# Altium schematic sheet Periferico.SchDoc(Periferico)
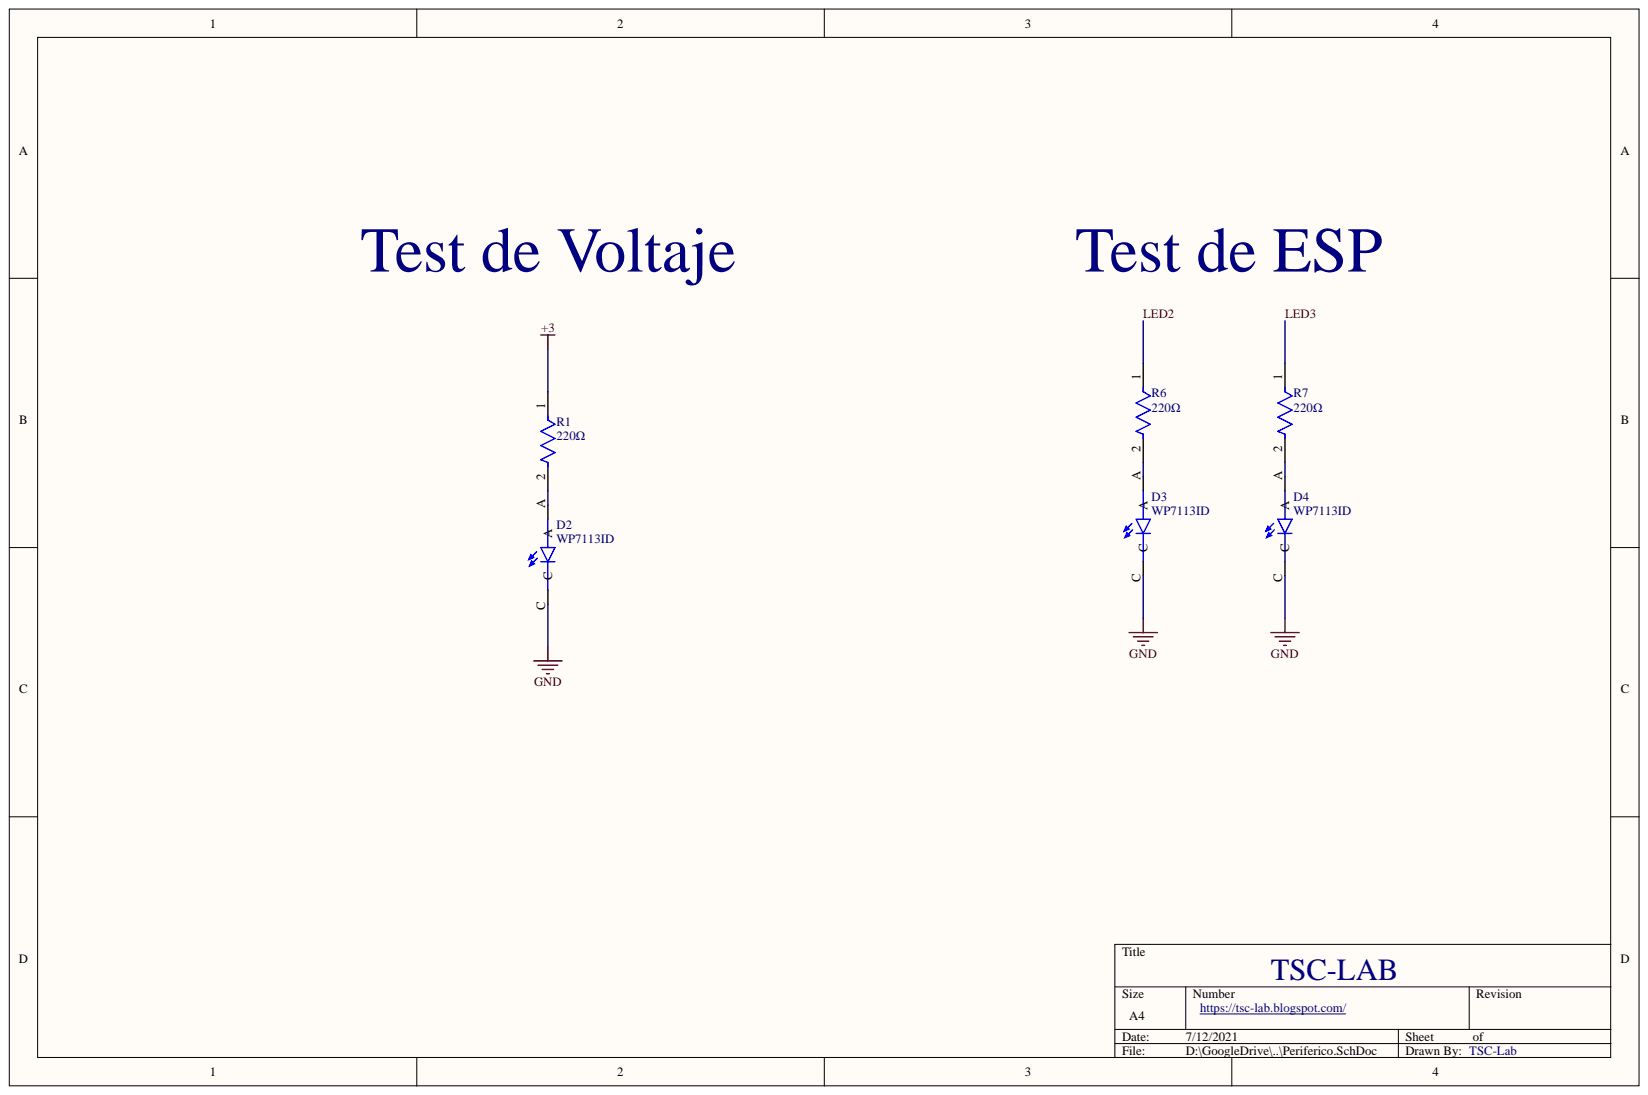
[diagram p0: Periferico.SchDoc]

## Parts
D2  WP7113ID  [LED_WP7113ID]
D3  WP7113ID  [LED_WP7113ID]
D4  WP7113ID  [LED_WP7113ID]
R1  value 220Ω  [Vishay_SFR2500002200FR500_0]  (hidden comment: Res_Vishay_SFR2500002200FR500_eec)
R6  value 220Ω  [Vishay_SFR2500002200FR500_0]  (hidden comment: Res_Vishay_SFR2500002200FR500_eec)
R7  value 220Ω  [Vishay_SFR2500002200FR500_0]  (hidden comment: Res_Vishay_SFR2500002200FR500_eec)

## Nets
+3: R1.1
GND: D2.C D3.C D4.C
LED2: R6.1
LED3: R7.1
NetD2_A: D2.A R1.2
NetD3_A: D3.A R6.2
NetD4_A: D4.A R7.2

## Library data of the parts
D2-D4 = WP7113ID: LED; Thru-Hole; Green; T1-3/4; 5mm; Clear Lens; 25ma; 565nm; 200mcd; Round; MF: {'manufacturer': u'Kingbright'}; Price: None; Package: None; MP: WP7113ID; Library Name: SnapEDA_Library.IntLib; Component Kind: Standard; Availability: Unavailable
R1 R6 R7 = Res_Vishay_SFR2500002200FR500_eec: RES 220 OHM 0.4W 1% AXIAL; Manufacturer: Vishay; voltage_rating_dc: 250 V; Symbol 0 0: Resistor; power_rating: 400 mW; Power: 400mW; Num Pins: 2; Category Cd01000Bfc2916C6: Single Components; Category 7542B8484461Ae85: Passive Components; Category 5C6A91606D4187Ad: Resistors; Category 5A00970A6D5C81C7: Uncategorized; Voltage: 250 V; Type: resistor; Tolerance: 1%; Temperature Range Low: -55°C; Temperature Range High: +155°C; Temperature: -55°C to +155°C; Supplier Part Number 2: 594-5043ED220R0F; Supplier Part Number 1: PPC220YCT-ND; Supplier 2: Mouser; Supplier 1: Digi-Key; RoHS: TRUE; Resistance: 220Ω; Price: 0.0503066408853; Part Number: SFR2500002200FR500; Package: AXIAL; Operating temperature range: -55 °C to 155 °C; Octopart Part Number: d6c31f7a8f400904; Mouser Part Number: 594-5043ED220R0F; Library Name: SnapEDA_Library.IntLib; Lead Free: yes; Ipc Land Pattern Name: RESAD1076W80L750D250; helpURL: https://upverter.com/upn/revision/077f2be647fd95a8/; Digikey Part Number: PPC220YCT-ND; Digikey Description: RES 220 OHM 0.4W 1% AXIAL; ComponentLink2URL: http://www.vishay.com/docs/28722/sfr16s25.pdf; ComponentLink2Description: Datasheet; ComponentLink1URL: https://upverter.com/upn/revision/077f2be647fd95a8/; ComponentLink1Description: Upverter; Component Kind: Standard
